# Altium schematic sheet CPU.SchDoc(CPU)
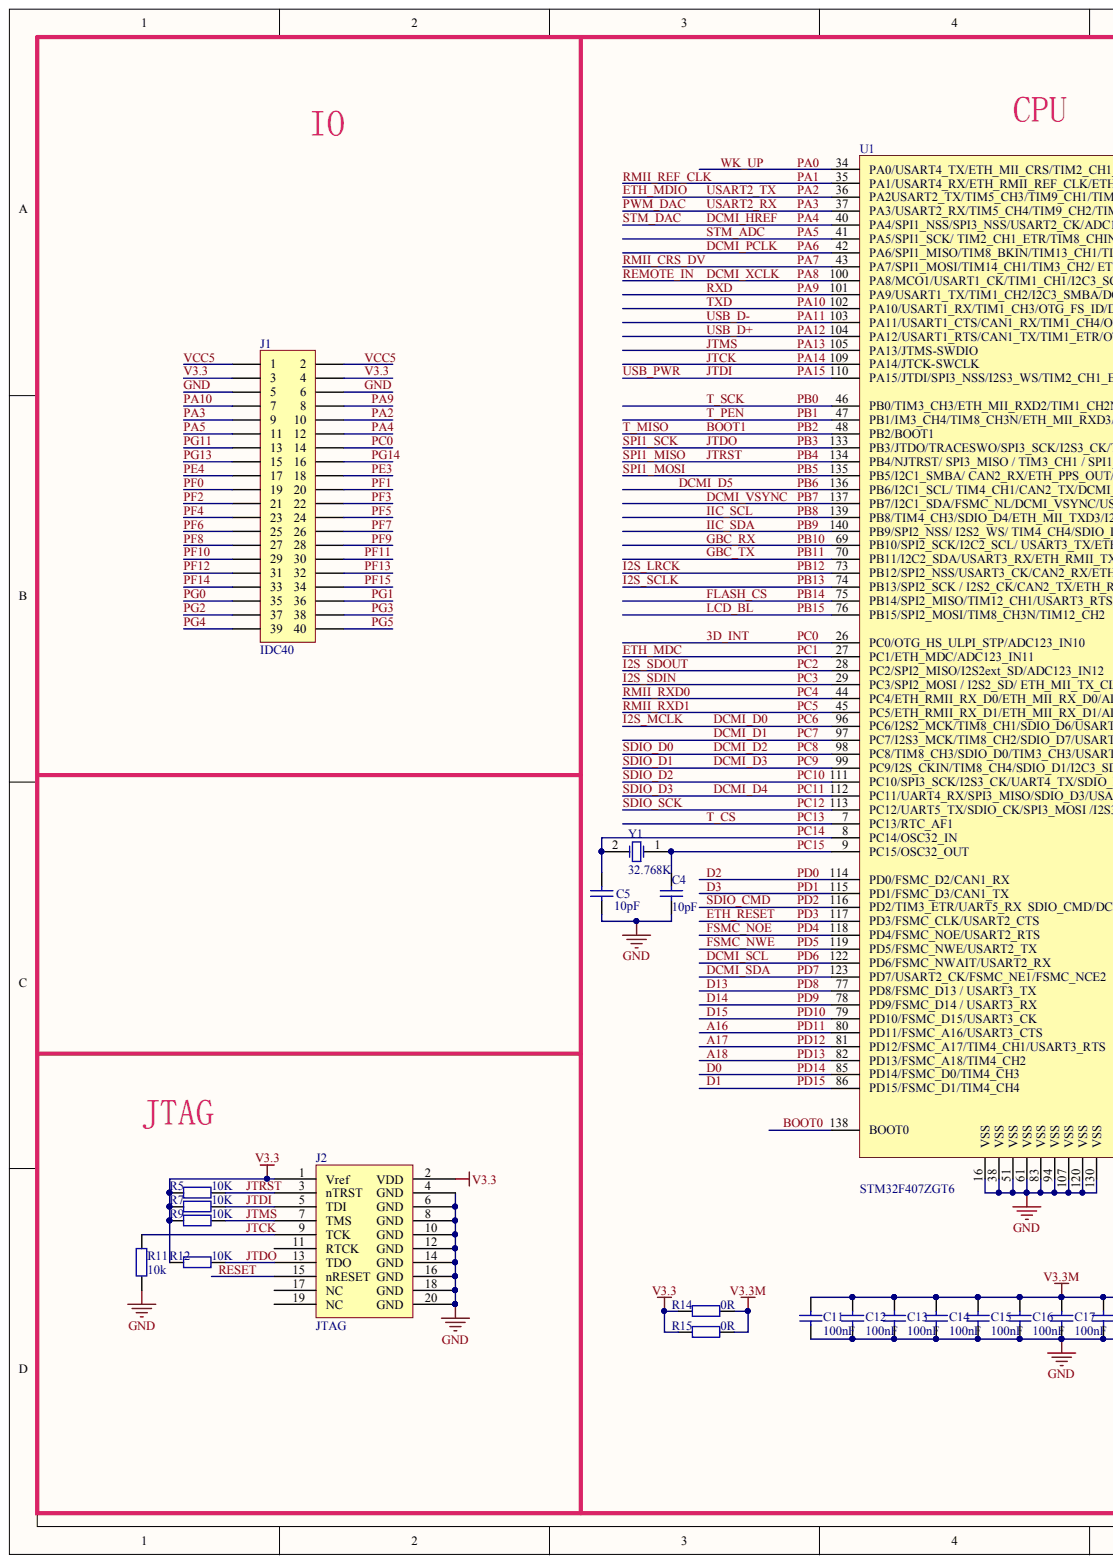
[diagram p0: CPU.SchDoc, left]
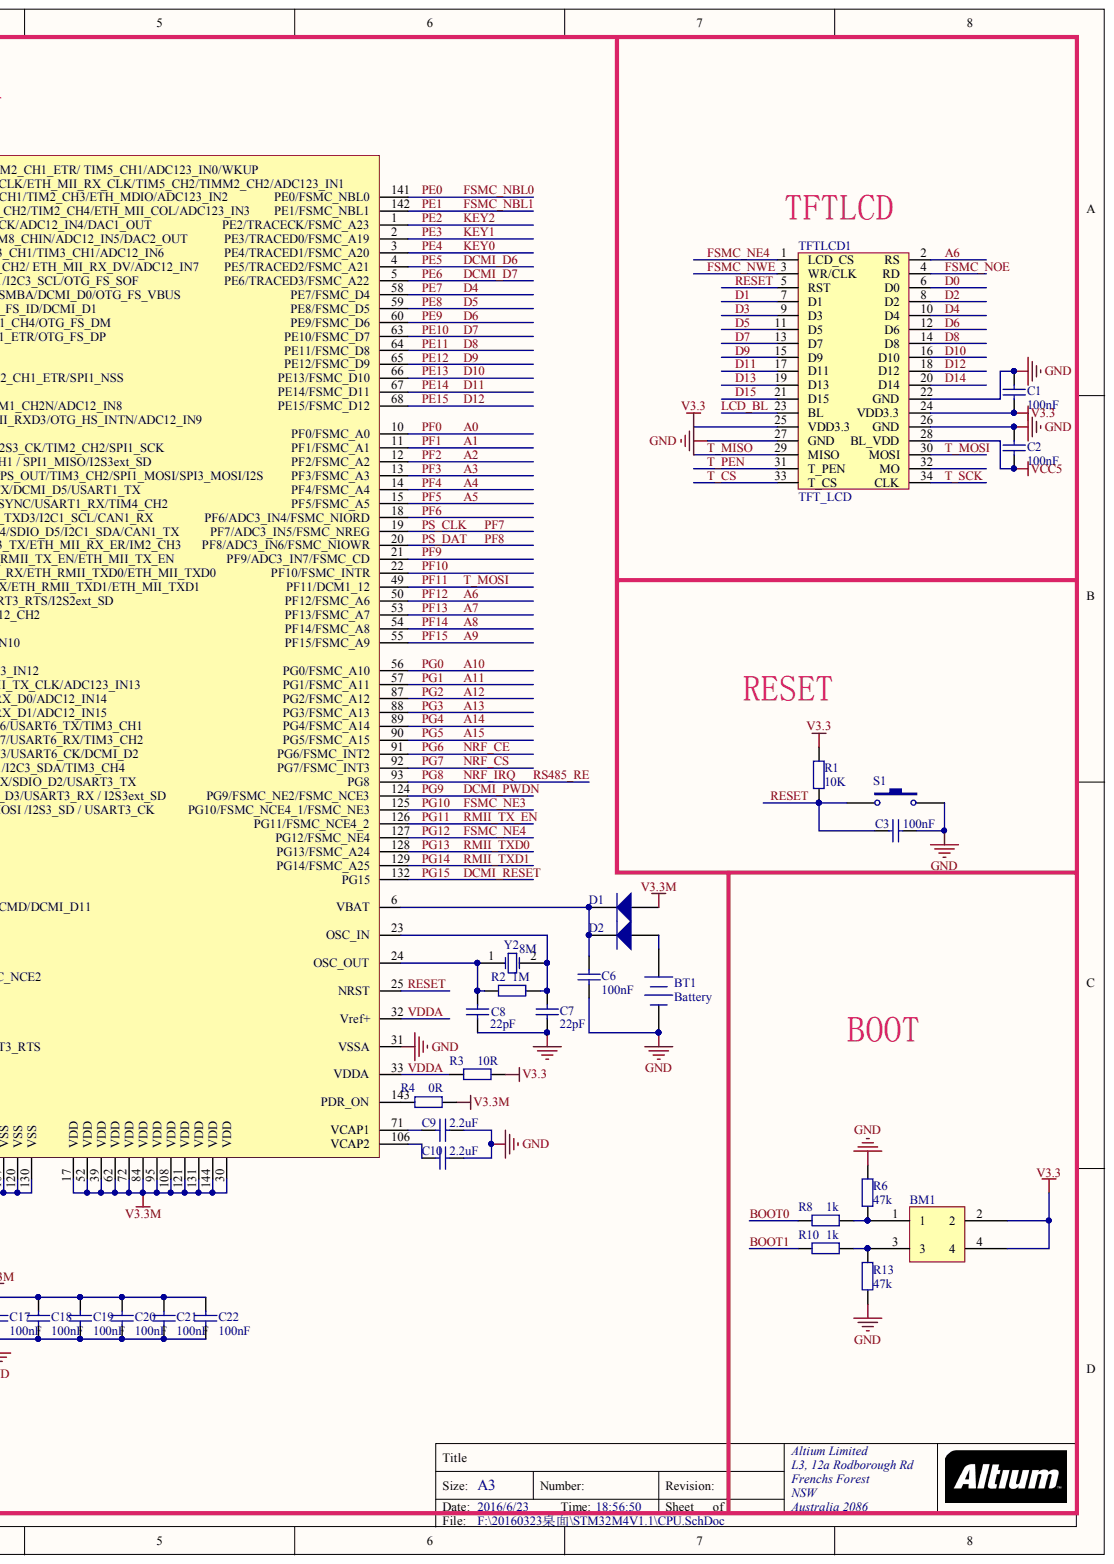
[diagram p1: CPU.SchDoc, right]

## Parts
BM1  BOMA  [BM]  (value not drawn)
BT1  Battery  [BATT]
C1  value 100nF  [0603]  (hidden comment: Cap)
C2  value 100nF  [0603]  (hidden comment: Cap)
C3  value 100nF  [0603]  (hidden comment: Cap)
C4  value 10pF  [0603]  (hidden comment: Cap)
C5  value 10pF  [0603]  (hidden comment: Cap)
C6  value 100nF  [0603]  (hidden comment: Cap)
C7  value 22pF  [0603]  (hidden comment: Cap)
C8  value 22pF  [0603]  (hidden comment: Cap)
C9  value 2.2uF  [0603]  (hidden comment: Cap)
C10  value 2.2uF  [0603]  (hidden comment: Cap)
C11  value 100nF  [0603]  (hidden comment: Cap)
C12  value 100nF  [0603]  (hidden comment: Cap)
C13  value 100nF  [0603]  (hidden comment: Cap)
C14  value 100nF  [0603]  (hidden comment: Cap)
C15  value 100nF  [0603]  (hidden comment: Cap)
C16  value 100nF  [0603]  (hidden comment: Cap)
C17  value 100nF  [0603]  (hidden comment: Cap)
C18  value 100nF  [0603]  (hidden comment: Cap)
C19  value 100nF  [0603]  (hidden comment: Cap)
C20  value 100nF  [0603]  (hidden comment: Cap)
C21  value 100nF  [0603]  (hidden comment: Cap)
C22  value 100nF  [0603]  (hidden comment: Cap)
D1  Diode  [IN4148 - duplicate]  (value not drawn)
D2  Diode  [IN4148 - duplicate]  (value not drawn)
J1  IDC40  [WPZ_2x20]
J2  JTAG  [WPZ_2x10]
R1  value 10K  [0603]  (hidden comment: Res2)
R2  value 1M  [0603]  (hidden comment: Res2)
R3  value 10R  [0603]  (hidden comment: Res2)
R4  value 0R  [0603]  (hidden comment: Res2)
R5  value 10K  [0603]  (hidden comment: Res2)
R6  value 47k  [0603]  (hidden comment: Res2)
R7  value 10K  [0603]  (hidden comment: Res2)
R8  value 1k  [0603]  (hidden comment: Res2)
R9  value 10K  [0603]  (hidden comment: Res2)
R10  value 1k  [0603]  (hidden comment: Res2)
R11  value 10k  [0603]  (hidden comment: Res2)
R12  value 10K  [0603]  (hidden comment: Res2)
R13  value 47k  [0603]  (hidden comment: Res2)
R14  value 0R  [0603]  (hidden comment: Res2)
R15  value 0R  [0603]  (hidden comment: Res2)
S1  SW-PB  [KEY]  (value not drawn)
TFTLCD1  TFT_LCD  [TFTLCD]
U1  STM32F407ZGT6  [LQFP144]
Y1  value 32.768K  [XTAL_32.768L]  (hidden comment: XTAL)
Y2  value 8M  [XTAL]  (hidden comment: XTAL)

## Nets
V3.3M: C11.2 C12.2 C13.2 C14.2 C15.2 C16.2 C17.2 C18.2 C19.2 C20.2 C21.2 C22.2 D1.1 R4.1 R14.1 R15.2 U1.17(VDD) U1.30(VDD) U1.39(VDD) U1.52(VDD) U1.62(VDD) U1.72(VDD) U1.84(VDD) U1.95(VDD) U1.108(VDD) U1.121(VDD) U1.131(VDD) U1.144(VDD)
3D_INT: J1.14 U1.26(PC0/OTG_HS_ULPI_STP/ADC123_IN10)
A0: J1.19 U1.10(PF0/FSMC_A0)
A1: J1.20 U1.11(PF1/FSMC_A1)
A2: J1.21 U1.12(PF2/FSMC_A2)
A3: J1.22 U1.13(PF3/FSMC_A3)
A4: J1.23 U1.14(PF4/FSMC_A4)
A5: J1.24 U1.15(PF5/FSMC_A5)
A6: J1.31 TFTLCD1.2(RS) U1.50(PF12/FSMC_A6)
A7: J1.32 U1.53(PF13/FSMC_A7)
A8: J1.33 U1.54(PF14/FSMC_A8)
A9: J1.34 U1.55(PF15/FSMC_A9)
A10: J1.35 U1.56(PG0/FSMC_A10)
A11: J1.36 U1.57(PG1/FSMC_A11)
A12: J1.37 U1.87(PG2/FSMC_A12)
A13: J1.38 U1.88(PG3/FSMC_A13)
A14: J1.39 U1.89(PG4/FSMC_A14)
A15: J1.40 U1.90(PG5/FSMC_A15)
A16: U1.80(PD11/FSMC_A16/USART3_CTS)
A17: U1.81(PD12/FSMC_A17/TIM4_CH1/USART3_RTS)
A18: U1.82(PD13/FSMC_A18/TIM4_CH2)
BOOT0: R8.2 U1.138(BOOT0)
BOOT1: R10.2 TFTLCD1.29(MISO) U1.48(PB2/BOOT1)
D0: TFTLCD1.6(D0) U1.85(PD14/FSMC_D0/TIM4_CH3)
D1: TFTLCD1.7(D1) U1.86(PD15/FSMC_D1/TIM4_CH4)
D2: TFTLCD1.8(D2) U1.114(PD0/FSMC_D2/CAN1_RX)
D3: TFTLCD1.9(D3) U1.115(PD1/FSMC_D3/CAN1_TX)
D4: TFTLCD1.10(D4) U1.58(PE7/FSMC_D4)
D5: TFTLCD1.11(D5) U1.59(PE8/FSMC_D5)
D6: TFTLCD1.12(D6) U1.60(PE9/FSMC_D6)
D7: TFTLCD1.13(D7) U1.63(PE10/FSMC_D7)
D8: TFTLCD1.14(D8) U1.64(PE11/FSMC_D8)
D9: TFTLCD1.15(D9) U1.65(PE12/FSMC_D9)
D10: TFTLCD1.16(D10) U1.66(PE13/FSMC_D10)
D11: TFTLCD1.17(D11) U1.67(PE14/FSMC_D11)
D12: TFTLCD1.18(D12) U1.68(PE15/FSMC_D12)
D13: TFTLCD1.19(D13) U1.77(PD8/FSMC_D13_/_USART3_TX)
D14: TFTLCD1.20(D14) U1.78(PD9/FSMC_D14_/_USART3_RX)
D15: TFTLCD1.21(D15) U1.79(PD10/FSMC_D15/USART3_CK)
DCMI_D0: U1.96(PC6/I2S2_MCK/TIM8_CH1/SDIO_D6/USART6_TX/TIM3_CH1)
DCMI_D1: U1.97(PC7/I2S3_MCK/TIM8_CH2/SDIO_D7/USART6_RX/TIM3_CH2)
DCMI_D2: U1.98(PC8/TIM8_CH3/SDIO_D0/TIM3_CH3/USART6_CK/DCMI_D2)
DCMI_D3: U1.99(PC9/I2S_CKIN/TIM8_CH4/SDIO_D1/I2C3_SDA/TIM3_CH4)
DCMI_D4: U1.112
DCMI_D5: U1.136(PB6/I2C1_SCL/_TIM4_CH1/CAN2_TX/DCMI_D5/USART1_TX)
DCMI_D6: U1.4(PE5/TRACED2/FSMC_A21)
DCMI_D7: U1.5(PE6/TRACED3/FSMC_A22)
DCMI_HREF: J1.12 U1.40(PA4/SPI1_NSS/SPI3_NSS/USART2_CK/ADC12_IN4/DAC1_OUT)
DCMI_PCLK: U1.42(PA6/SPI1_MISO/TIM8_BKIN/TIM13_CH1/TIM3_CH1/ADC12_IN6)
DCMI_PWDN: U1.124(PG9/FSMC_NE2/FSMC_NCE3)
DCMI_RESET: U1.132(PG15)
DCMI_SCL: U1.122(PD6/FSMC_NWAIT/USART2_RX)
DCMI_SDA: U1.123(PD7/USART2_CK/FSMC_NE1/FSMC_NCE2)
DCMI_VSYNC: U1.137(PB7/I2C1_SDA/FSMC_NL/DCMI_VSYNC/USART1_RX/TIM4_CH2)
DCMI_XCLK: U1.100(PA8/MCO1/USART1_CK/TIM1_CH1/I2C3_SCL/OTG_FS_SOF)
ETH_MDC: U1.27(PC1/ETH_MDC/ADC123_IN11)
ETH_MDIO: J1.10 U1.36(PA2USART2_TX/TIM5_CH3/TIM9_CH1/TIM2_CH3/ETH_MDIO/ADC123_IN2)
ETH_RESET: U1.117(PD3/FSMC_CLK/USART2_CTS)
FLASH_CS: U1.75(PB14/SPI2_MISO/TIM12_CH1/USART3_RTS/I2S2ext_SD)
FSMC_NBL0: U1.141(PE0/FSMC_NBL0)
FSMC_NBL1: U1.142(PE1/FSMC_NBL1)
FSMC_NE3: U1.125(PG10/FSMC_NCE4_1/FSMC_NE3)
FSMC_NE4: TFTLCD1.1(LCD_CS) U1.127(PG12/FSMC_NE4)
FSMC_NOE: TFTLCD1.4(RD) U1.118(PD4/FSMC_NOE/USART2_RTS)
FSMC_NWE: TFTLCD1.3(WR/CLK) U1.119(PD5/FSMC_NWE/USART2_TX)
GBC_RX: U1.69(PB10/SPI2_SCK/I2C2_SCL/_USART3_TX/ETH_MII_RX_ER/IM2_CH3)
GBC_TX: U1.70(PB11/I2C2_SDA/USART3_RX/ETH_RMII_TX_EN/ETH_MII_TX_EN)
GND: BT1.2 C1.1 C2.1 C3.1 C4.1 C5.1 C6.1 C7.1 C8.1 C9.1 C10.1 C11.1 C12.1 C13.1 C14.1 C15.1 C16.1 C17.1 C18.1 C19.1 C20.1 C21.1 C22.1 J1.5 J1.6 J2.4(GND) J2.6(GND) J2.8(GND) J2.10(GND) J2.12(GND) J2.14(GND) J2.16(GND) J2.18(GND) J2.20(GND) R6.1 R11.1 R13.2 S1.2 TFTLCD1.22(GND) TFTLCD1.26(GND) TFTLCD1.27(GND) U1.16(VSS) U1.31(VSSA) U1.38(VSS) U1.51(VSS) U1.61(VSS) U1.83(VSS) U1.94(VSS) U1.107(VSS) U1.120(VSS) U1.130(VSS)
I2S_LRCK: U1.73(PB12/SPI2_NSS/USART3_CK/CAN2_RX/ETH_RMII_TXD0/ETH_MII_TXD0)
I2S_SCLK: U1.74(PB13/SPI2_SCK_/_I2S2_CK/CAN2_TX/ETH_RMII_TXD1/ETH_MII_TXD1)
I2S_SDIN: U1.29(PC3/SPI2_MOSI_/_I2S2_SD/_ETH_MII_TX_CLK/ADC123_IN13)
I2S_SDOUT: U1.28(PC2/SPI2_MISO/I2S2ext_SD/ADC123_IN12)
IIC_SCL: U1.139(PB8/TIM4_CH3/SDIO_D4/ETH_MII_TXD3/I2C1_SCL/CAN1_RX)
IIC_SDA: U1.140(PB9/SPI2_NSS/_I2S2_WS/_TIM4_CH4/SDIO_D5/I2C1_SDA/CAN1_TX)
JTCK: J2.9(TCK) R11.2 U1.109(PA14/JTCK-SWCLK)
JTDI: J2.5(TDI) R7.2 U1.110(PA15/JTDI/SPI3_NSS/I2S3_WS/TIM2_CH1_ETR/SPI1_NSS)
JTDO: J2.13(TDO) R12.2 U1.133(PB3/JTDO/TRACESWO/SPI3_SCK/I2S3_CK/TIM2_CH2/SPI1_SCK)
JTMS: J2.7(TMS) R9.2 U1.105(PA13/JTMS-SWDIO)
JTRST: J2.3(nTRST) R5.2 U1.134
KEY0: J1.17 U1.3(PE4/TRACED1/FSMC_A20)
KEY1: J1.18 U1.2(PE3/TRACED0/FSMC_A19)
KEY2: U1.1(PE2/TRACECK/FSMC_A23)
LCD_BL: TFTLCD1.23(BL) U1.76(PB15/SPI2_MOSI/TIM8_CH3N/TIM12_CH2)
NRF_CE: U1.91(PG6/FSMC_INT2)
NRF_CS: U1.92(PG7/FSMC_INT3)
NRF_IRQ: U1.93(PG8)
PA0: U1.34(PA0/USART4_TX/ETH_MII_CRS/TIM2_CH1_ETR/_TIM5_CH1/ADC123_IN0/WKUP)
PA1: U1.35(PA1/USART4_RX/ETH_RMII_REF_CLK/ETH_MII_RX_CLK/TIM5_CH2/TIMM2_CH2/ADC123_IN1)
PA3: J1.9 U1.37(PA3/USART2_RX/TIM5_CH4/TIM9_CH2/TIM2_CH4/ETH_MII_COL/ADC123_IN3)
PA5: J1.11 U1.41(PA5/SPI1_SCK/_TIM2_CH1_ETR/TIM8_CHIN/ADC12_IN5/DAC2_OUT)
PA7: U1.43
PA9: J1.8 U1.101(PA9/USART1_TX/TIM1_CH2/I2C3_SMBA/DCMI_D0/OTG_FS_VBUS)
PA10: J1.7 U1.102(PA10/USART1_RX/TIM1_CH3/OTG_FS_ID/DCMI_D1)
PA11: U1.103(PA11/USART1_CTS/CAN1_RX/TIM1_CH4/OTG_FS_DM)
PA12: U1.104(PA12/USART1_RTS/CAN1_TX/TIM1_ETR/OTG_FS_DP)
PB0: TFTLCD1.34(CLK) U1.46(PB0/TIM3_CH3/ETH_MII_RXD2/TIM1_CH2N/ADC12_IN8)
PB1: TFTLCD1.31(T_PEN) U1.47(PB1/IM3_CH4/TIM8_CH3N/ETH_MII_RXD3/OTG_HS_INTN/ADC12_IN9)
PB5: U1.135(PB5/I2C1_SMBA/_CAN2_RX/ETH_PPS_OUT/TIM3_CH2/SPI1_MOSI/SPI3_MOSI/I2S)
PC4: U1.44(PC4/ETH_RMII_RX_D0/ETH_MII_RX_D0/ADC12_IN14)
PC5: U1.45(PC5/ETH_RMII_RX_D1/ETH_MII_RX_D1/ADC12_IN15)
PC10: U1.111(PC10/SPI3_SCK/I2S3_CK/UART4_TX/SDIO_D2/USART3_TX)
PC12: U1.113
PC13: TFTLCD1.33(T_CS) U1.7(PC13/RTC_AF1)
PC14: C5.2 U1.8(PC14/OSC32_IN) Y1.2
PC15: C4.2 U1.9(PC15/OSC32_OUT) Y1.1
PD2: U1.116(PD2/TIM3_ETR/UART5_RX)
PF6: J1.25 U1.18(PF6/ADC3_IN4/FSMC_NIORD)
PF7: J1.26 U1.19(PF7/ADC3_IN5/FSMC_NREG)
PF8: J1.27 U1.20(PF8/ADC3_IN6/FSMC_NIOWR)
PF9: J1.28 U1.21(PF9/ADC3_IN7/FSMC_CD)
PF10: J1.29 U1.22(PF10/FSMC_INTR)
PF11: J1.30 TFTLCD1.30(MOSI) U1.49(PF11/DCM1_12)
PG11: J1.13 U1.126(PG11/FSMC_NCE4_2)
PG13: J1.15 U1.128(PG13/FSMC_A24)
PG14: J1.16 U1.129(PG14/FSMC_A25)
RESET: C3.2 J2.15(nRESET) R1.1 S1.1 TFTLCD1.5(RST) U1.25(NRST)
V3.3: BM1.2 BM1.4 C1.2 J1.3 J1.4 J2.1(Vref) J2.2(VDD) R1.2 R3.1 R5.1 R7.1 R9.1 R12.1 R14.2 R15.1 TFTLCD1.24(VDD3.3) TFTLCD1.25(VDD3.3)
VCC5: C2.2 J1.1 J1.2 TFTLCD1.28(BL_VDD)
VDDA: R3.2 U1.32(Vref+) U1.33(VDDA)
NetBM1_1: BM1.1 R6.2 R8.1
NetBM1_3: BM1.3 R10.1 R13.1
NetBT1_1: BT1.1 D2.1
NetC6_2: C6.2 D1.2 D2.2 U1.6(VBAT)
NetC7_2: C7.2 R2.2 U1.23(OSC_IN) Y2.2
NetC8_2: C8.2 R2.1 U1.24(OSC_OUT) Y2.1
NetC9_2: C9.2 U1.71(VCAP1)
NetC10_2: C10.2 U1.106(VCAP2)
NetR4_2: R4.2 U1.143(PDR_ON)

Not connected: J2 11(RTCK) 17(NC) 19(NC); TFTLCD1 32(MO)

## Library data of the parts
BT1 = Battery: Multicell Battery; Component Kind: Standard; LatestRevisionDate: 17-Jul-2002; LatestRevisionNote: Re-released for DXP Platform.; Library Name: M4.SCHLIB; PackageDescription: Battery; 2 Leads; PackageReference: BAT-2; Published: 24-Mar-1999; Publisher: Altium Limited
C1-C22 = Cap: Capacitor; Component Kind: Standard; LatestRevisionDate: 17-Jul-2002; LatestRevisionNote: Re-released for DXP Platform.; Library Name: Miscellaneous Devices.IntLib; PackageDescription: Radial Cap, Thru-Hole; 2 Leads; 0.3 in Pin Spacing; PackageReference: RAD-0.3; Published: 8-Jun-2000; Signal Integrity: Cap; Simulation: CAP; Publisher: Altium Limited
D1 D2 = Diode: Default Diode; Code_JEDEC: DO-214-AB; Component Kind: Standard; LatestRevisionDate: 17-Jul-2002; LatestRevisionNote: Re-released for DXP Platform.; Library Name: Miscellaneous Devices.IntLib; PackageDescription: DO-214-AB/SMC; 2 C-Bend Leads; Body 7.9 x 5.9 mm, inc. leads (LxW); PackageReference: SMC; PackageVersion: Sep-1996; Published: 8-Jun-2000; Publisher: Altium Limited
  D2: Signal Integrity: Diode; Simulation: DIODE
J1 = MHDR2X20: Header, 20-Pin, Dual row; Component Kind: Standard; LatestRevisionDate: 17-Jul-2002; LatestRevisionNote: Re-released for DXP Platform.; Library Name: AX301.SCHLIB; Signal Integrity: Connector; Publisher: Altium Limited
R1-R15 = Res2: Resistor; Component Kind: Standard; LatestRevisionDate: 17-Jul-2002; LatestRevisionNote: Re-released for DXP Platform.; PackageDescription: Axial Device, Thru-Hole; 2 Leads; 0.4 in Pin Spacing; PackageReference: AXIAL-0.4; Published: 8-Jun-2000; Signal Integrity: Res; Simulation: RESISTOR; Publisher: Altium Limited
  R1-R10 R12 R13 R15: Library Name: Miscellaneous Devices.IntLib
  R11 R14: Library Name: M4.SCHLIB
S1 = SW-PB: Switch; Component Kind: Standard; LatestRevisionDate: 17-Jul-2002; LatestRevisionNote: Re-released for DXP Platform.; Library Name: Miscellaneous Devices.IntLib; PackageDescription: Switch; 2 Leads; PackageReference: SPST-2; Published: 24-Mar-1999; Publisher: Altium Limited
Y1 Y2 = XTAL: Crystal Oscillator; Component Kind: Standard; LatestRevisionDate: 17-Jul-2002; LatestRevisionNote: Re-released for DXP Platform.; Library Name: Miscellaneous Devices.IntLib; PackageDescription: Crystal, Thru-Hole; 2 Leads; Body 3.1 x 8.2 mm (Dia.xH); PackageReference: R38; Published: 8-Jun-2000; Simulation: XTAL; Publisher: Altium Limited
BM1 = BOMA: Component Kind: Standard; Library Name: WARSHIP.SCHLIB
J2 = JTAG: Component Kind: Standard; Library Name: MyLibrary.IntLib
TFTLCD1 = TFT_LCD: Component Kind: Standard; Library Name: MyLibrary.IntLib
U1 = STM32F407ZGT6: Component Kind: Standard; Library Name: MyLibrary.IntLib
